# Altium schematic sheet JTAG.SchDoc
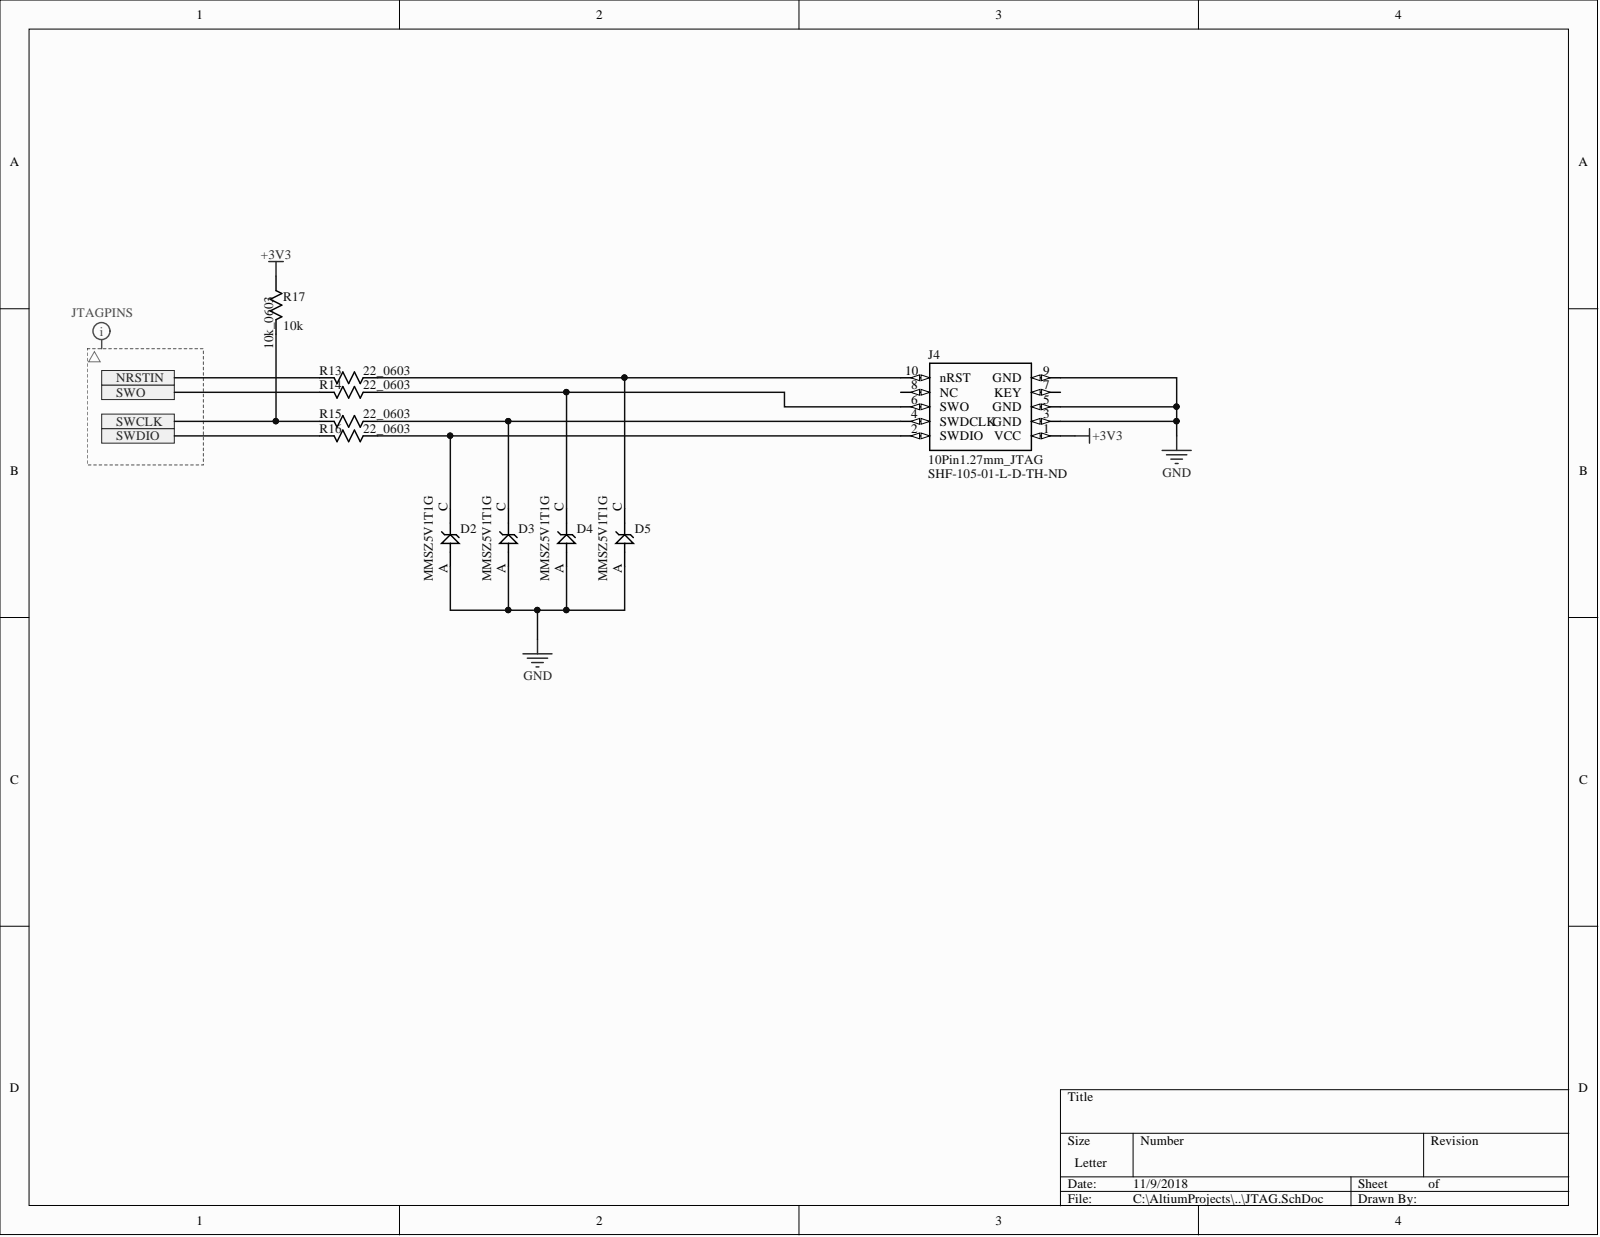
[diagram p0: JTAG.SchDoc]

## Parts
D2  MMSZ5V1T1G  [SOIC127P370X94-2N]
D3  MMSZ5V1T1G  [SOIC127P370X94-2N]
D4  MMSZ5V1T1G  [SOIC127P370X94-2N]
D5  MMSZ5V1T1G  [SOIC127P370X94-2N]
J4  10Pin1.27mm_JTAG  Supplier Part Number 1: SHF-105-01-L-D-TH-ND  [10Pin1.27mm]
R13  22_0603  [0603]
R14  22_0603  [0603]
R15  22_0603  [0603]
R16  22_0603  [0603]
R17  10k_0603  Resistance: 10k  [0603]

## Ports
NRSTIN, SWCLK, SWDIO, SWO

## Nets
+3V3: J4.1(VCC) R17.2
GND: D2.A D3.A D4.A D5.A J4.3(GND) J4.5(GND) J4.9(GND)
NRSTIN: R13.1 ; port NRSTIN
SWCLK: R15.1 R17.1 ; port SWCLK
SWDIO: R16.1 ; port SWDIO
SWO: R14.1 ; port SWO
NetD2_C: D2.C J4.2(SWDIO) R16.2
NetD3_C: D3.C J4.4(SWDCLK) R15.2
NetD4_C: D4.C J4.6(SWO) R14.2
NetD5_C: D5.C J4.10(nRST) R13.2
N00162:

Not connected: J4 7(KEY) 8(NC)

## Library data of the parts
D2-D5 = MMSZ5V1T1G: DIODE Zener 5.1V 500mw; Manufacturer: ON Semiconductor; Breakdown Voltage: 5.36V; Element Configuration: Single; Forward Voltage: 900mV; Impedance: 60R; Material: Plastic; Max Reverse Leakage Current: 2uA; Test Current: 5mA; Tolerance: 5%; Zener Current: 10mA; Case/Package: SOD-123-2; Component Kind: Standard; ComponentLink1Description: https://datasheet.ciiva.com/895/mmsz2v4t1-d-895025.pdf; ComponentLink1URL: https://datasheet.ciiva.com/895/mmsz2v4t1-d-895025.pdf; ComponentLink2Description: https://datasheet.ciiva.com/895/mmsz2v4t1-d-895026.pdf; ComponentLink2URL: https://datasheet.ciiva.com/895/mmsz2v4t1-d-895026.pdf; ComponentLink3Description: https://datasheet.ciiva.com/895/mmsz2v4t1-d-895027.pdf; ComponentLink3URL: https://datasheet.ciiva.com/895/mmsz2v4t1-d-895027.pdf; ComponentLink4Description: https://datasheet.ciiva.com/15142/getdatasheetpartid-72078-15142387.pdf; ComponentLink4URL: https://datasheet.ciiva.com/15142/getdatasheetpartid-72078-15142387.pdf; ComponentLink5Description: https://datasheet.ciiva.com/8346/mmsz2v4t1-d-8346086.pdf; ComponentLink5URL: https://datasheet.ciiva.com/8346/mmsz2v4t1-d-8346086.pdf; ComponentLink6Description: https://datasheet.ciiva.com/8026/78656-8026888.pdf; ComponentLink6URL: https://datasheet.ciiva.com/8026/78656-8026888.pdf; ComponentLink7Description: https://datasheet.ciiva.com/26092/mmsz2v4t1-d-26092696.pdf; ComponentLink7URL: https://datasheet.ciiva.com/26092/mmsz2v4t1-d-26092696.pdf; ComponentLink8Description: https://datasheet.ciiva.com/16542/mmsz2v4t1-d-16542073.pdf; ComponentLink8URL: https://datasheet.ciiva.com/16542/mmsz2v4t1-d-16542073.pdf; ComponentLink9Description: https://datasheet.ciiva.com/8026/78656-8026890.pdf; ComponentLink9URL: https://datasheet.ciiva.com/8026/78656-8026890.pdf; Height: 1.12mm; Lead Free: Lead Free; Length: 2.69mm; Library Name: Diodes.IntLib; Manufacturer Part Number: MMSZ5V1T1G; Max Operating Temperature: 150°C; Max Power Dissipation: 500mW; Min Operating Temperature: -55°C; Number of Pins: 2; Package Quantity: 1; Packaging: Tape and Reel; Peak Reverse Current: 2uA; Power Dissipation: 500mW; Power Rating: 500mW; Radiation Hardening: No; REACH SVHC: No SVHC; Stock 1: 0; Supplier 1: Future Electronics; Supplier Part Number 1: MMSZ5V1T1G; Voltage Rating (DC): 5.1V; Voltage Tolerance: 5%; Width: 1.6mm; Working Voltage: 5.1V; Zener Voltage: 5.1V
J4 = 10Pin1.27mm_JTAG: Manufacturer: Samtec; Manufacturer Part Number: SHF-105-01-L-D-TH; Gender: Male; Number of Contacts: 10; Pitch: 1.27mm; Component Kind: Standard; ComponentLink1Description: https://datasheet.ciiva.com/9475/eshf-9475367.pdf; ComponentLink1URL: https://datasheet.ciiva.com/9475/eshf-9475367.pdf; ComponentLink2Description: https://datasheet.ciiva.com/22665/shf-22665879.pdf; ComponentLink2URL: https://datasheet.ciiva.com/22665/shf-22665879.pdf; ComponentLink3Description: https://datasheet.ciiva.com/6091/shf-6091993.pdf; ComponentLink3URL: https://datasheet.ciiva.com/6091/shf-6091993.pdf; ComponentLink4Description: https://datasheet.ciiva.com/16710/eshf-16710363.pdf; ComponentLink4URL: https://datasheet.ciiva.com/16710/eshf-16710363.pdf; ComponentLink5Description: https://datasheet.ciiva.com/23730/shf-1xx-01-x-d-xx-xx-mkt-23730878.pdf; ComponentLink5URL: https://datasheet.ciiva.com/23730/shf-1xx-01-x-d-xx-xx-mkt-23730878.pdf; Contact Material: Bronze; Contact Plating: Nickel,Gold; Depth: 5.59mm; Housing Colour: Black; Housing Material: Polymer; Length: 12.7mm; Library Name: Connectors.IntLib; Max Operating Temperature: 125°C; Min Operating Temperature: -55°C; Number of Rows: 2; Orientation: Straight; Packaging: Bulk; Radiation Hardening: No; REACH SVHC: No SVHC; Stock 1: 0; Supplier 1: Digi-Key; Termination: Solder
R13-R16 = 22_0603: Manufacturer: Vishay; Manufacturer Part Number: CRCW060322R0FKEA; Power Rating: 100mW; Resistance: 22R; Temperature Coefficient: 100ppm/°C; Tolerance: 1%; Voltage Rating: 75V; Case/Package: 0603; Case Code (Imperial): 0603; Case Code (Metric): 1608; Component Kind: Standard; ComponentLink1Description: https://datasheet.ciiva.com/16382/dcrcwe3-16382261.pdf; ComponentLink1URL: https://datasheet.ciiva.com/16382/dcrcwe3-16382261.pdf; ComponentLink2Description: https://datasheet.ciiva.com/5552/dcrcwe3-109170-5552120.pdf; ComponentLink2URL: https://datasheet.ciiva.com/5552/dcrcwe3-109170-5552120.pdf; ComponentLink3Description: https://datasheet.ciiva.com/22700/1697337-22700361.pdf; ComponentLink3URL: https://datasheet.ciiva.com/22700/1697337-22700361.pdf; ComponentLink4Description: https://datasheet.ciiva.com/11809/0900766b80d98afc-11809067.pdf; ComponentLink4URL: https://datasheet.ciiva.com/11809/0900766b80d98afc-11809067.pdf; ComponentLink5Description: https://datasheet.ciiva.com/7672/1697337-7672876.pdf; ComponentLink5URL: https://datasheet.ciiva.com/7672/1697337-7672876.pdf; ComponentLink6Description: https://datasheet.ciiva.com/15159/getdatasheetpartid-33090-15159388.pdf; ComponentLink6URL: https://datasheet.ciiva.com/15159/getdatasheetpartid-33090-15159388.pdf; ComponentLink7Description: https://datasheet.ciiva.com/7672/1697337-7672877.pdf; ComponentLink7URL: https://datasheet.ciiva.com/7672/1697337-7672877.pdf; ComponentLink8Description: https://datasheet.ciiva.com/26316/fld-crcw-e3-data-en-26316590.pdf; ComponentLink8URL: https://datasheet.ciiva.com/26316/fld-crcw-e3-data-en-26316590.pdf; ComponentLink9Description: https://datasheet.ciiva.com/16893/dcrcw-16893903.pdf; ComponentLink9URL: https://datasheet.ciiva.com/16893/dcrcw-16893903.pdf; Composition: Thick Film; Contact Plating: Tin,Lead; Depth: 0.85mm; Height: 0.45mm; Lead Free: Lead Free; Length: 1.55mm; Library Name: Resistors.IntLib; Max Operating Temperature: 155°C; Military Standard: Not; Min Operating Temperature: -55°C; Mount: Surface Mount; Package Quantity: 5000; Packaging: Tape and Reel; Pricing 1: 5000=0.00497, 10000=0.00432, 25000=0.00405 (USD); Radiation Hardening: No; REACH SVHC: Unknown; Stock 1: 0; Supplier 1: Digi-Key; Supplier Part Number 1: 541-22.0HTR-ND; Termination: SMD/SMT; Voltage Rating (DC): 75V; Weight: 7.1E-05oz; Width: 0.85mm
R17 = 10k_0603: Manufacturer: Panasonic; Manufacturer Part Number: ERJ-3EKF1002V; Max Power Dissipation: 100mW; Temperature Coefficient: 100ppm/°C; Tolerance: 1%; Voltage Rating: 75V; Case/Package: 0603; Case Code (Imperial): 0603; Case Code (Metric): 1608; Component Kind: Standard; ComponentLink1Description: https://datasheet.ciiva.com/16511/aoa0000ce2-16511861.pdf; ComponentLink1URL: https://datasheet.ciiva.com/16511/aoa0000ce2-16511861.pdf; ComponentLink2Description: https://datasheet.ciiva.com/46372/1678782-46372725.pdf; ComponentLink2URL: https://datasheet.ciiva.com/46372/1678782-46372725.pdf; ComponentLink3Description: https://datasheet.ciiva.com/28514/426256-28514198.pdf; ComponentLink3URL: https://datasheet.ciiva.com/28514/426256-28514198.pdf; ComponentLink4Description: https://datasheet.ciiva.com/9194/aoa0000ce2-9194199.pdf; ComponentLink4URL: https://datasheet.ciiva.com/9194/aoa0000ce2-9194199.pdf; ComponentLink5Description: https://datasheet.ciiva.com/7830/aoa0000ce2-7830729.pdf; ComponentLink5URL: https://datasheet.ciiva.com/7830/aoa0000ce2-7830729.pdf; ComponentLink6Description: https://datasheet.ciiva.com/17212/aoa0000ce2-17212105.pdf; ComponentLink6URL: https://datasheet.ciiva.com/17212/aoa0000ce2-17212105.pdf; ComponentLink7Description: https://datasheet.ciiva.com/30208/aoa0000ce2-30208985.pdf; ComponentLink7URL: https://datasheet.ciiva.com/30208/aoa0000ce2-30208985.pdf; ComponentLink8Description: https://datasheet.ciiva.com/48530/aoa0000ce2-48530988.pdf; ComponentLink8URL: https://datasheet.ciiva.com/48530/aoa0000ce2-48530988.pdf; ComponentLink9Description: https://datasheet.ciiva.com/24070/aoa0000ce2-24070318.pdf; ComponentLink9URL: https://datasheet.ciiva.com/24070/aoa0000ce2-24070318.pdf; ComponentLink10Description: https://datasheet.ciiva.com/16759/70260748-16759807.pdf; ComponentLink10URL: https://datasheet.ciiva.com/16759/70260748-16759807.pdf; Composition: Thick Film; Contact Plating: Tin; Depth: 0.8mm; Height: 0.45mm; Lead Free: Lead Free; Length: 1.6mm; Library Name: Resistors.IntLib; Max Operating Temperature: 155°C; Military Standard: Not; Min Operating Temperature: -55°C; Mount: Surface Mount; Number of Pins: 2; Number of Terminations: 2; Package Quantity: 1; Packaging: Tape and Reel; Power Rating: 100mW; Pricing 1: 5000=0.00751, 10000=0.00653, 25000=0.00573, 50000=0.00526, 125000=0.00515 (USD); Radiation Hardening: No; REACH SVHC: No SVHC; Stock 1: 0; Supplier 1: Digi-Key; Supplier Part Number 1: P10.0KHTR-ND; Termination: SMD/SMT; Voltage Rating (DC): 75V; Width: 0.031inch
